# Altium schematic sheet MCU_Peripherals.SchDoc(MCU_Peripherals)
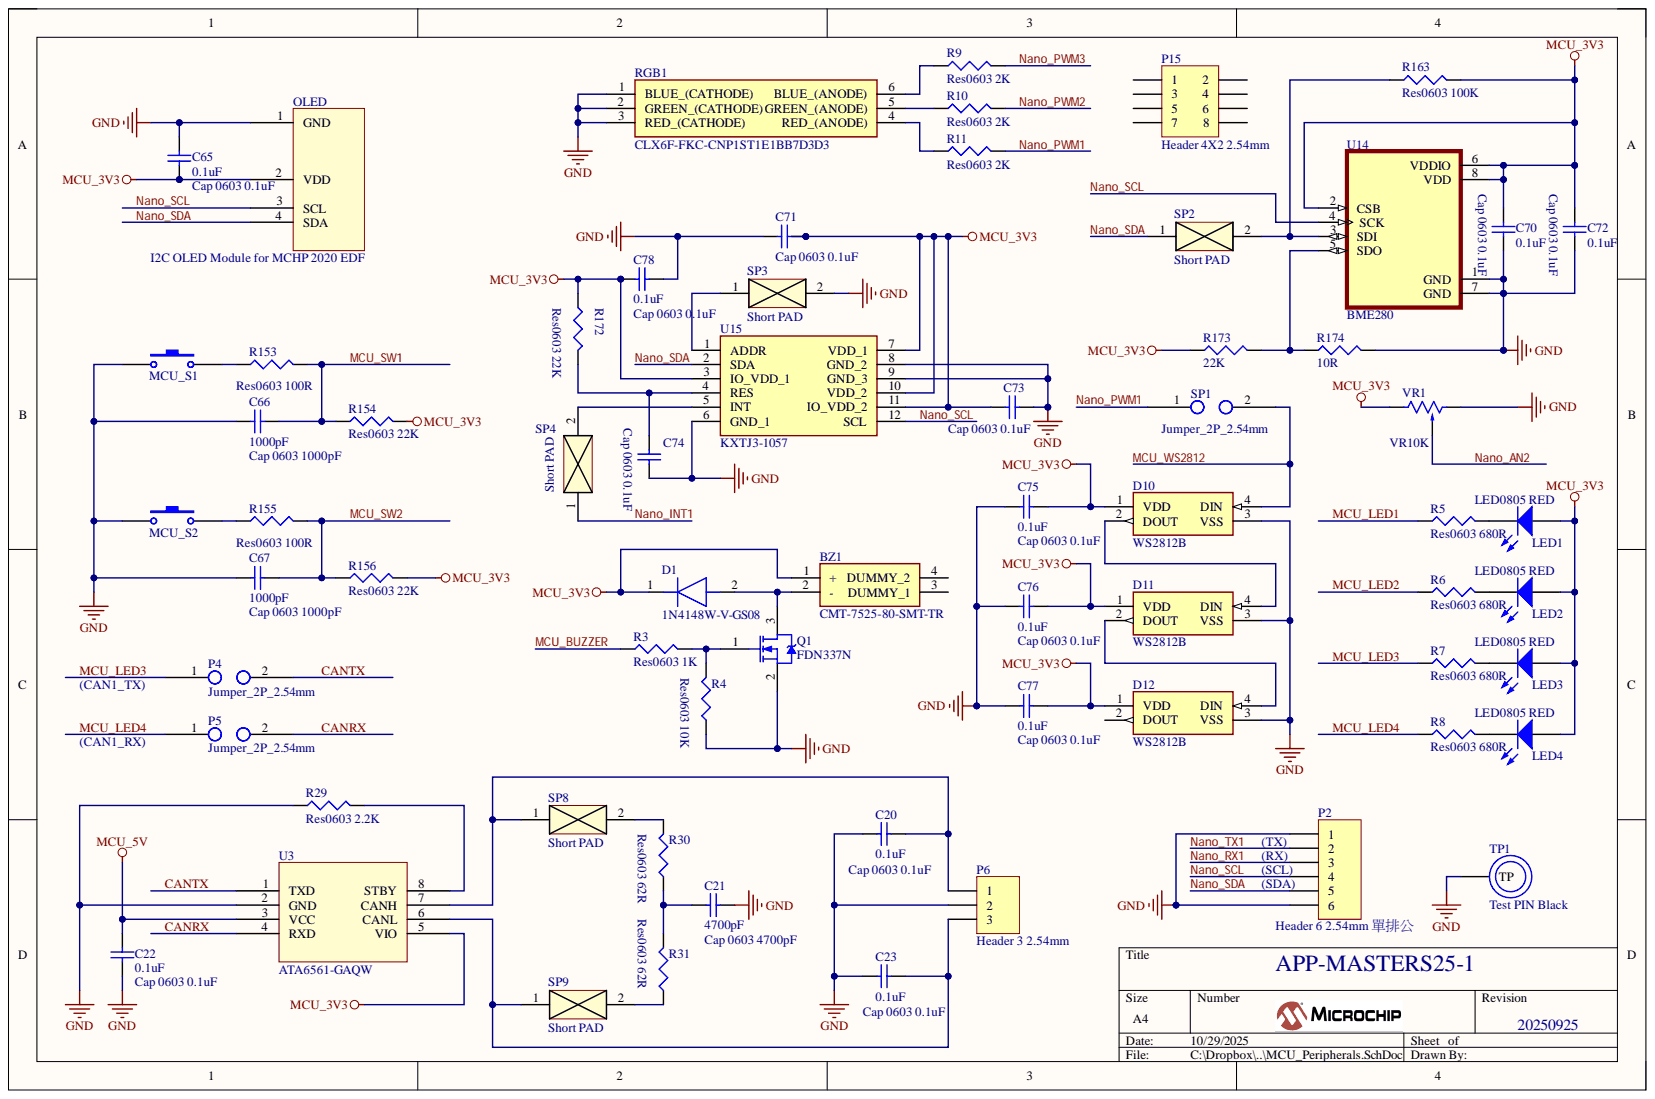
[diagram p0: MCU_Peripherals.SchDoc]

## Parts
BZ1  CMT-7525-80-SMT-TR  [CMT752580SMTTR]
C20  Cap 0603 0.1uF  value 0.1uF  [0603C]
C21  Cap 0603 4700pF  value 4700pF  [0603C]
C22  Cap 0603 0.1uF  value 0.1uF  [0603C]
C23  Cap 0603 0.1uF  value 0.1uF  [0603C]
C65  Cap 0603 0.1uF  value 0.1uF  [0603C]
C66  Cap 0603 1000pF  value 1000pF  [0603C]
C67  Cap 0603 1000pF  value 1000pF  [0603C]
C70  Cap 0603 0.1uF  value 0.1uF  [0603C]
C71  Cap 0603 0.1uF  value 0.1uF  [0603C]
C72  Cap 0603 0.1uF  value 0.1uF  [0603C]
C73  Cap 0603 0.1uF  value 0.1uF  [0603C]
C74  Cap 0603 0.1uF  value 0.1uF  [0603C]
C75  Cap 0603 0.1uF  value 0.1uF  [0603C]
C76  Cap 0603 0.1uF  value 0.1uF  [0603C]
C77  Cap 0603 0.1uF  value 0.1uF  [0603C]
C78  Cap 0603 0.1uF  value 0.1uF  [0603C]
D1  1N4148W-V-GS08  [1N4148WVGS08]
D10  WS2812B  [WS2812B]
D11  WS2812B  [WS2812B]
D12  WS2812B  [WS2812B]
LED1  LED0805 RED  [LED0805_R]
LED2  LED0805 RED  [LED0805_R]
LED3  LED0805 RED  [LED0805_R]
LED4  LED0805 RED  [LED0805_R]
MCU_S1  SW-PB  [Button_SMD]  (value not drawn)
MCU_S2  SW-PB  [Button_SMD]  (value not drawn)
OLED  I2C OLED Module for MCHP 2020 EDF  [OLED_I2C_POWER_CLICK]
P2  Header 6 2.54mm 單排公  [HDR1X6]
P4  Jumper_2P_2.54mm  [HDR1X2]
P5  Jumper_2P_2.54mm  [HDR1X2]
P6  Header 3 2.54mm  [HDR1X3]
P15  Header 4X2 2.54mm  [HDR2X4]
Q1  FDN337N  [MMBT_SOT23_N]
R3  Res0603 1K  value 1K  [0603R]
R4  Res0603 10K  value 10K  [0603R]
R5  Res0603 680R  [0603R]
R6  Res0603 680R  [0603R]
R7  Res0603 680R  [0603R]
R8  Res0603 680R  [0603R]
R9  Res0603 2K  value 2K  [0603R]
R10  Res0603 2K  value 2K  [0603R]
R11  Res0603 2K  value 2K  [0603R]
R29  Res0603 2.2K  value 2.2K  [0603R]
R30  Res0603 62R  value 62R  [0603R]
R31  Res0603 62R  value 62R  [0603R]
R153  Res0603 100R  value 100R  [0603R]
R154  Res0603 22K  value 22K  [0603R]
R155  Res0603 100R  value 100R  [0603R]
R156  Res0603 22K  value 22K  [0603R]
R163  Res0603 100K  value 100K  [0603R]
R172  Res0603 22K  value 22K  [0603R]
R173  Res0603 22K  value 22K  [0603R]
R174  value 10R  [0603R]  (hidden comment: Res0603 10R)
RGB1  CLX6F-FKC-CNP1ST1E1BB7D3D3  [PLCC6]
SP1  Jumper_2P_2.54mm  [HDR1X2]
SP2  Short PAD  [Short_PAD]
SP3  Short PAD  [Short_PAD]
SP4  Short PAD  [Short_PAD]
SP8  Short PAD  [Short_PAD]
SP9  Short PAD  [Short_PAD]
TP1  Test PIN Black  [PIN1]
U3  ATA6561-GAQW  [8S1_M]
U14  BME280  [PSON65P250X250X100-8N]
U15  KXTJ3-1057  [KXTJ3-1057 2X2X0.9MMLGA]
VR1  VR10K  [MCHP_VR10K]

## Nets
GND: C20.2 C21.2 C22.1 C23.2 C65.2 C66.1 C67.1 C70.1 C71.2 C72.1 C73.1 C74.1 C75.1 C76.1 C77.1 C78.2 D10.3(VSS) D11.3(VSS) D12.3(VSS) MCU_S1.1 MCU_S2.1 OLED.1(GND) P2.1 P2.6 P6.2 Q1.2 R4.1 R29.1 R174.2 RGB1.1(BLUE_(CATHODE)) RGB1.2(GREEN_(CATHODE)) RGB1.3(RED_(CATHODE)) SP3.2 TP1.1 U3.2(GND) U14.1(GND) U14.7(GND) U15.6(GND_1) U15.8(GND_2) U15.9(GND_3) VR1.2
MCU_3V3: BZ1.1(+) C65.1 C70.2 C71.1 C72.2 C73.2 C75.2 C76.2 C77.2 C78.1 D1.1 D10.1(VDD) D11.1(VDD) D12.1(VDD) LED1.1 LED2.1 LED3.1 LED4.1 OLED.2(VDD) R154.2 R156.2 R163.2 R172.1 R173.1 U3.5(VIO) U14.2(CSB) U14.6(VDDIO) U14.8(VDD) U15.3(IO_VDD_1) U15.7(VDD_1) U15.10(VDD_2) U15.11(IO_VDD_2) VR1.1
MCU_5V: C22.2 U3.3(VCC)
CANRX: P5.2 U3.4(RXD)
CANTX: P4.2 U3.1(TXD)
MCU_BUZZER: R3.1
MCU_LED1: R5.1
MCU_LED2: R6.1
MCU_LED3: P4.1 R7.1
MCU_LED4: P5.1 R8.1
MCU_SW1: C66.2 R153.2 R154.1
MCU_SW2: C67.2 R155.2 R156.1
MCU_WS2812: D10.4(DIN) SP1.2
Nano_AN2: VR1.3
Nano_INT1: SP4.1
Nano_PWM1: R11.2 SP1.1
Nano_PWM2: R10.2
Nano_PWM3: R9.2
Nano_RX1: P2.3
Nano_SCL: OLED.3(SCL) P2.4 U14.4(SCK) U15.12(SCL)
Nano_SDA: OLED.4(SDA) P2.5 SP2.1 U15.2(SDA)
Nano_TX1: P2.2
NetBZ1_2: BZ1.2(-) D1.2 Q1.3
NetC20_1: C20.1 P6.1 SP8.1 U3.7(CANH)
NetC21_1: C21.1 R30.1 R31.2
NetC23_1: C23.1 P6.3 SP9.1 U3.6(CANL)
NetC74_2: C74.2 R172.2 U15.4(RES)
NetD10_2: D10.2(DOUT) D11.4(DIN)
NetD11_2: D11.2(DOUT) D12.4(DIN)
NetLED1_2: LED1.2 R5.2
NetLED2_2: LED2.2 R6.2
NetLED3_2: LED3.2 R7.2
NetLED4_2: LED4.2 R8.2
NetMCU_S1_2: MCU_S1.2 R153.1
NetMCU_S2_2: MCU_S2.2 R155.1
NetQ1_1: Q1.1 R3.2 R4.2
NetR9_1: R9.1 RGB1.6(BLUE_(ANODE))
NetR10_1: R10.1 RGB1.5(GREEN_(ANODE))
NetR11_1: R11.1 RGB1.4(RED_(ANODE))
NetR29_2: R29.2 U3.8(STBY)
NetR30_2: R30.2 SP8.2
NetR31_1: R31.1 SP9.2
NetR163_1: R163.1 SP2.2 U14.3(SDI)
NetR173_2: R173.2 R174.1 U14.5(SDO)
NetSP3_1: SP3.1 U15.1(ADDR)
NetSP4_2: SP4.2 U15.5(INT)

Not connected: BZ1 3(DUMMY_1) 4(DUMMY_2); D12 2(DOUT); P15 1 2 3 4 5 6 7 8

## Library data of the parts
BZ1 = CMT-7525-80-SMT-TR: Loudspeaker or Buzzer; Manufacturer_Name: Same Sky; Arrow Part Number: CMT-7525-80-SMT-TR; Arrow Price/Stock: https://www.arrow.com/en/products/cmt-7525-80-smt-tr/cui-devices?region=nac; Component Kind: Standard; Datasheet Link: https://www.sameskydevices.com/product/resource/cmt-7525-80-smt-tr.pdf; Height: 2.7mm; Library Name: SamacSys.SchLib; Manufacturer_Part_Number: CMT-7525-80-SMT-TR; Mouser Part Number: 490-CMT-7525-80SMTTR; Mouser Price/Stock: https://www.mouser.co.uk/ProductDetail/Same-Sky/CMT-7525-80-SMT-TR?qs=P1JMDcb91o5izP7Xira9ww%3D%3D
C20-C23 C65-C67 C70-C78 = Cap 0603: Capacitor (Semiconductor SIM Model); Component Kind: Standard; LatestRevisionDate: 29-May-2009; LatestRevisionNote: IPC-7351 Footprint Added.; Library Name: MCHP_Parts_2016.SchLib; PackageDescription: Chip Capacitor; PackageReference: C0603; Published: 8-Jun-2000; Publisher: Altium Limited
  C71: Value: 0.1uF
D1 = 1N4148W-V-GS08: Diode; Manufacturer_Name: Vishay; Arrow Part Number: 1N4148W-V-GS08; Arrow Price/Stock: https://www.arrow.com/en/products/1n4148w-v-gs08/vishay; Component Kind: Standard; Datasheet Link: https://us.rs-online.com/m/d/a2789c8794cb0c42ced29f2dd8571f3c.pdf; Height: 1.35mm; Library Name: SamacSys.SchLib; Manufacturer_Part_Number: 1N4148W-V-GS08; Mouser Part Number: 625-1N4148W-V; Mouser Price/Stock: https://www.mouser.co.uk/ProductDetail/Vishay-Semiconductors/1N4148W-V-GS08?qs=TNTIDjy6APrS%2Fdgq1RSs3A%3D%3D
D10-D12 = WS2812B: Integrated Circuit; Manufacturer_Name: Worldsemi; Component Kind: Standard; Datasheet Link: https://www.adafruit.com/datasheets/WS2812B.pdf; Library Name: SamacSys.SchLib; Manufacturer_Part_Number: WS2812B
LED1-LED4 = LED0805 RED: Typical BLUE SiC LED; Component Kind: Standard; DatasheetVersion: 16-Apr-2002; LatestRevisionDate: 17-Dec-2003; LatestRevisionNote: PCB Footprint replaced.; Library Name: MCHP_Parts_2016.SchLib; PackageDescription: SM LED; 2 C-Bend Leads; Body 3.5 x 2.8 x 1.9 mm, inc leads (LxWxH typ); PackageReference: 3.5X2.8X1.9; PackageVersion: 16-Apr-2002; Published: 8-Jun-2000; Publisher: Altium Limited
MCU_S1 MCU_S2 = SW-PB: Switch; Component Kind: Standard; LatestRevisionDate: 17-Jul-2002; LatestRevisionNote: Re-released for DXP Platform.; Library Name: MCHP_Parts_2016.SchLib; PackageDescription: Switch; 2 Leads; PackageReference: SPST-2; Published: 24-Mar-1999; Publisher: Altium Limited
OLED = OLED_I2C_2020_PowerClick: Component Kind: Standard; Library Name: MCHP_Parts_2016.SchLib
P2 = Header 6: Header, 6-Pin; Component Kind: Standard; LatestRevisionDate: 17-Jul-2002; LatestRevisionNote: Re-released for DXP Platform.; Library Name: MCHP_Parts_2016.SchLib; Publisher: Altium Limited
P6 = Header 3: Header, 3-Pin; Component Kind: Standard; LatestRevisionDate: 17-Jul-2002; LatestRevisionNote: Re-released for DXP Platform.; Library Name: MCHP_Parts_2016.SchLib; Publisher: Altium Limited
P15 = Header 4X2: Header, 4-Pin, Dual row; Component Kind: Standard; LatestRevisionDate: 17-Jul-2002; LatestRevisionNote: Re-released for DXP Platform.; Library Name: MCHP_Parts_2016.SchLib; Publisher: Altium Limited
Q1 = FDN337N: N Channel MOSFET; Code_JEDEC: TO-254-AA; Component Kind: Standard; ComponentLink1Description: Manufacturer Link; ComponentLink1URL: http://www.irf.com/; ComponentLink2Description: Datasheet; ComponentLink2URL: http://www.irf.com/product-info/datasheets/data/IRFM460.pdf; DatasheetVersion: PD-9.486C; Library Name: MCHP_Parts_2016.SchLib; PackageDescription: TO, Thru-Hole, Vertical, Heatsink Mounted; 3 In-Line Leads; Pitch 3.81 mm; PackageReference: TO-254AA; Published: 17-Sep-2003; Publisher: Altium Limited
R3 R4 R9-R11 R29-R31 R153-R156 R163 R172-R174 = Res0603: Resistor; Component Kind: Standard; LatestRevisionDate: 29-May-2009; LatestRevisionNote: IPC-7351 Footprint Added.; Library Name: MCHP_Parts_2016.SchLib; PackageDescription: Chip Resistor; PackageReference: J1-0603; Published: 8-Jun-2000; Publisher: Altium Limited
  R31: Value: 62R
R5-R8 = Res0603: Resistor; Value: 680; Component Kind: Standard; LatestRevisionDate: 29-May-2009; LatestRevisionNote: IPC-7351 Footprint Added.; Library Name: MCHP_Parts_2016.SchLib; PackageDescription: Chip Resistor; PackageReference: J1-0603; Published: 8-Jun-2000; Publisher: Altium Limited
RGB1 = CLX6F-FKC-CNP1ST1E1BB7D3D3: LED; Manufacturer_Name: CREE LED; Arrow Part Number: CLX6F-FKC-CNP1ST1E1BB7D3D3; Arrow Price/Stock: https://www.arrow.com/en/products/clx6f-fkc-cnp1st1e1bb7d3d3/cree-led?utm_currency=USD&region=europe; Component Kind: Standard; Datasheet Link: http://www.cree.com/led-components/media/documents/ds-CLX6F-FKC-1352.pdf; Library Name: SamacSys.SchLib; Manufacturer_Part_Number: CLX6F-FKC-CNP1ST1E1BB7D3D3; Mouser Part Number: 941-CLX6FFKCCNP1ST1E; Mouser Price/Stock: https://www.mouser.co.uk/ProductDetail/Cree-LED/CLX6F-FKC-CNP1ST1E1BB7D3D3?qs=wU1J8Md1npzbKznVTnfRUQ%3D%3D
SP2-SP4 SP8 SP9 = Short_Pads: Component Kind: Standard; Library Name: MCHP_Parts_2016.SchLib
TP1 = Test PIN: Component Kind: Standard; Description: Test Pin; LatestRevisionDate: 17-Jul-2002; LatestRevisionNote: Re-released for DXP Platform.; Library Name: MCHP_Parts_2016.SchLib; Publisher: Altium Limited
U14 = BME280: Humidity Temperature Sensor 0 ~ 100% RH IÂ²C, SPI Â±3% 1s Surface Mount; MF: Bosch; Availability: Unavailable; Component Kind: Standard; Library Name: MCHP_Parts_2016.SchLib; MP: BME280; Package: LGA-8 Bosch; Price: None; SnapEDA_Link: https://www.snapeda.com/parts/BME280/Bosch%20Sensortec/view-part/49769/?ref=snap
U15 = KXTJ3-1057: Accelerometer; Manufacturer_Name: Kionix; Component Kind: Standard; Datasheet Link: https://componentsearchengine.com/Datasheets/1/KXTJ3-1057.pdf; Library Name: MCHP_Parts_2016.SchLib; Manufacturer_Part_Number: KXTJ3-1057; Mouser Part Number: 912-KXTJ3-1057; Mouser Price/Stock: https://www.mouser.com/Search/Refine.aspx?Keyword=912-KXTJ3-1057
P4 P5 SP1 = Jumper_2P_2.54mm: Component Kind: Standard; Library Name: MCHP_Parts_2016.SchLib
U3 = ATA6561-GAQW: Component Kind: Standard; Library Name: MCHP_Parts_2016.SchLib
VR1 = VR10K: Component Kind: Standard; Library Name: MCHP_Parts_2016.SchLib
